# Altium schematic sheet Power.SchDoc
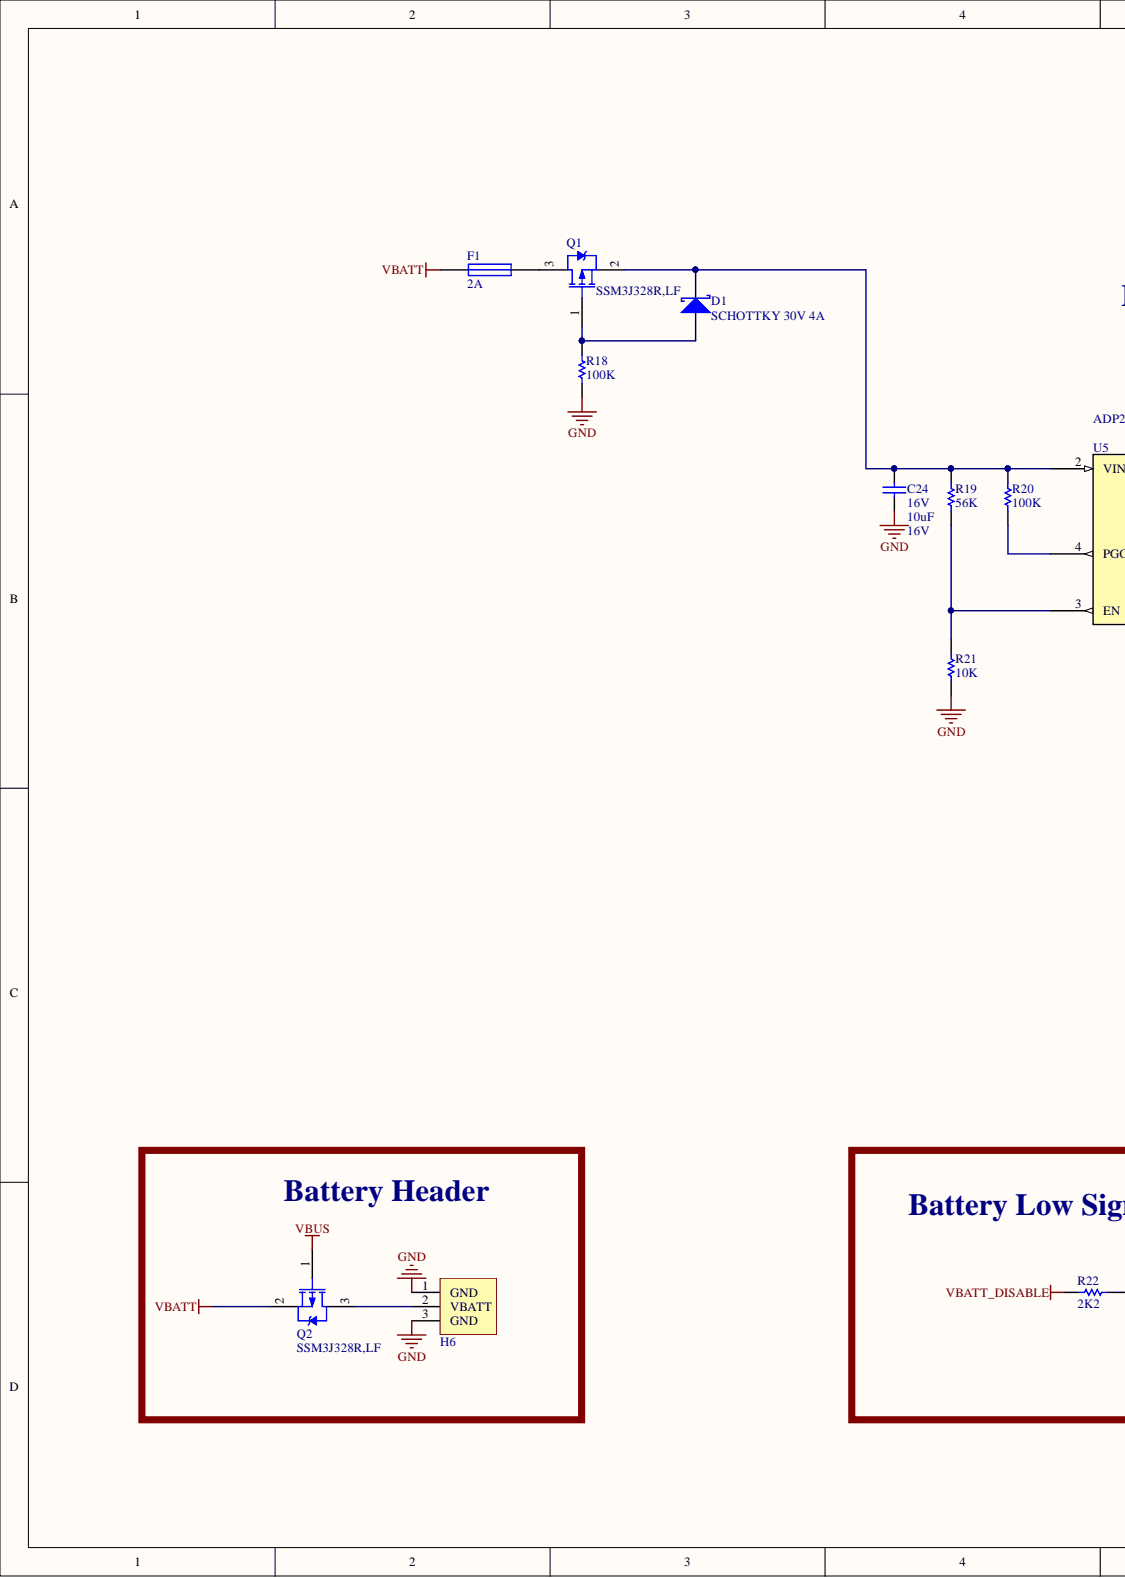
[diagram p0: Power.SchDoc, left]
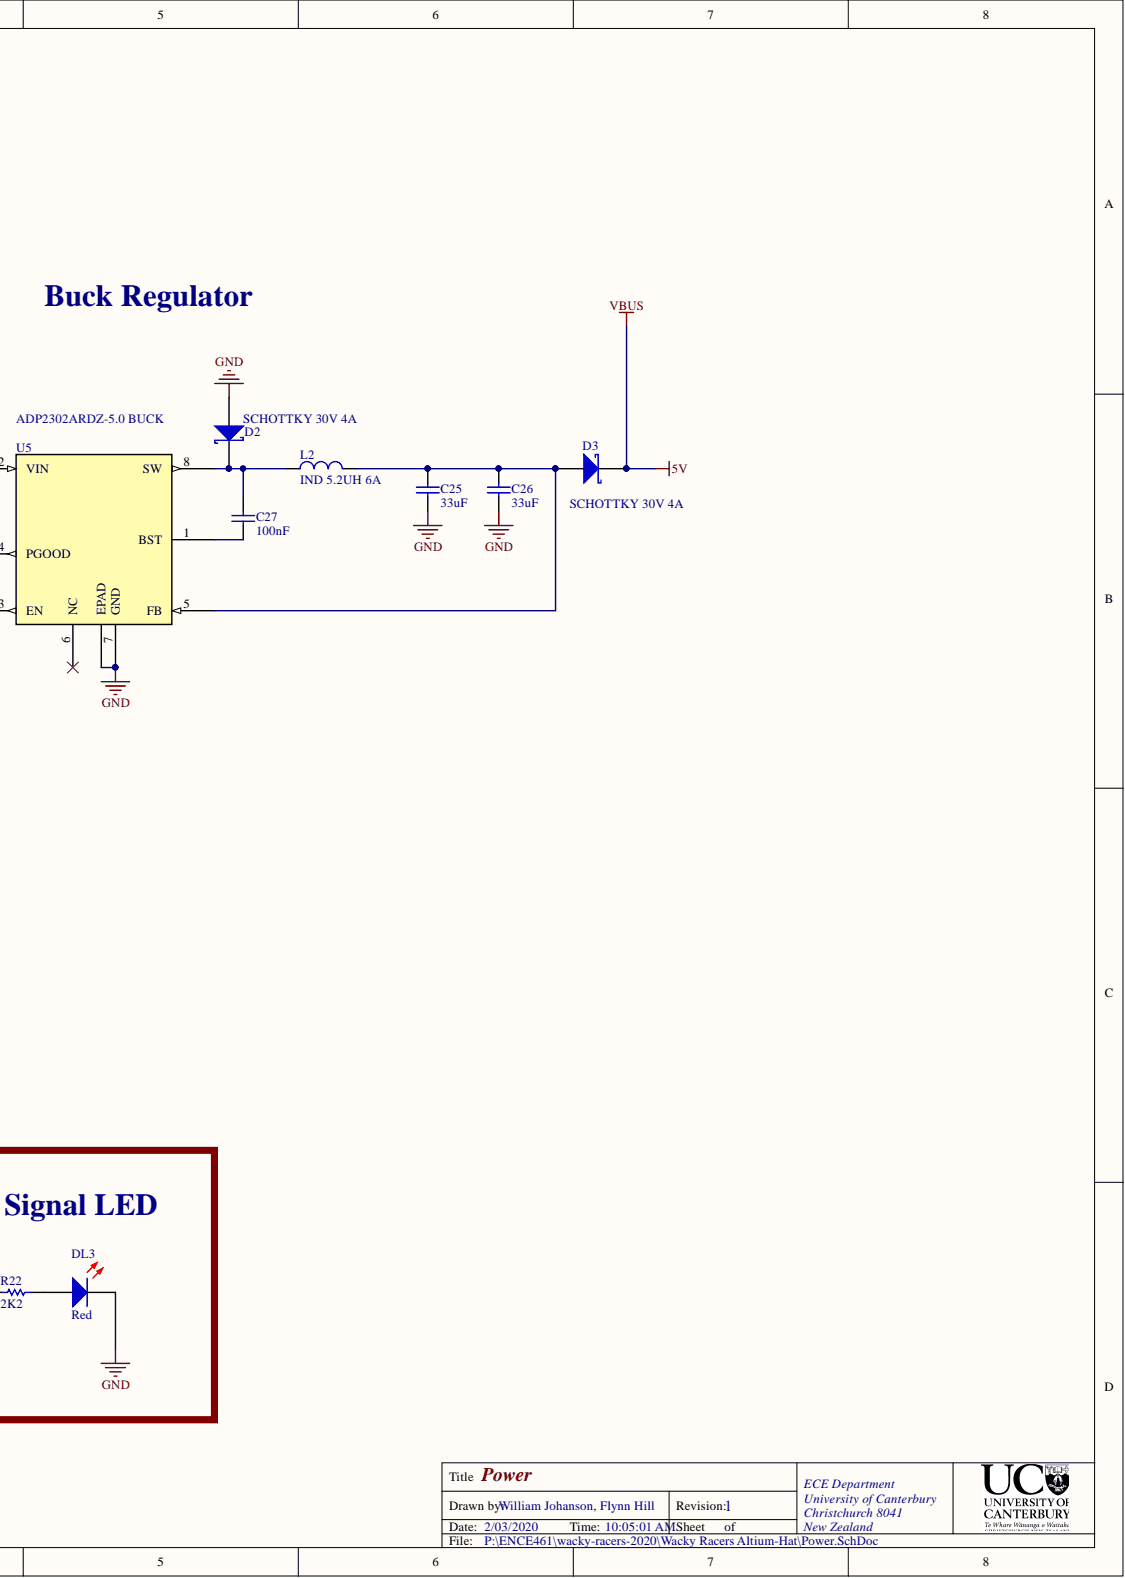
[diagram p1: Power.SchDoc, right]

## Parts
C24  10uF  Max Voltage: 16V  [CAPC2012L]
C25  33uF  [CAPC2012L]
C26  33uF  [CAPC2012L]
C27  100nF  [CAPC1608L]
D1  SCHOTTKY 30V 4A  [SMC]
D2  SCHOTTKY 30V 4A  [SMC]
D3  SCHOTTKY 30V 4A  [SMC]
DL3  Red  [WL-SMCW0603]
F1  2A  [RESC3216N]
H6  Battery_HEADER_3pin  [BATT_HEADER_3POS]  (value not drawn)
L2  IND 5.2UH 6A  [INDUCTOR5u2]
Q1  SSM3J328R,LF  [SOT23_L]
Q2  SSM3J328R,LF  [SOT23_L]
R18  100K  [RESC1608L]
R19  56K  [RESC1608L]
R20  100K  [RESC1608L]
R21  10K  [RESC1608L]
R22  2K2  [RESC1608L]
U5  ADP2302ARDZ-5.0 BUCK  [RD_8_1]

## Nets
5V: D3.2 Q2.1
GND: C24.1 C25.1 C26.1 D2.1 DL3.1 H6.1 H6.3 R18.1 R21.1 U5.7(GND) U5.EPAD
VBATT: F1.2 Q2.2
VBATT_DISABLE: R22.1
NetC24_2: C24.2 D1.2 Q1.2 R19.2 R20.2 U5.2(VIN)
NetC25_2: C25.2 C26.2 D3.1 L2.2 U5.5(FB)
NetC27_1: C27.1 U5.1(BST)
NetC27_2: C27.2 D2.2 L2.1 U5.8(SW)
NetD1_1: D1.1 Q1.1 R18.2
NetDL3_2: DL3.2 R22.2
NetF1_1: F1.1 Q1.3
NetH6_2: H6.2 Q2.3
NetR19_1: R19.1 R21.2 U5.3(EN)
NetR20_1: R20.1 U5.4(PGOOD)

Not connected: U5 6(NC)

## Library data of the parts
C24 = CC_10uF_16V_0805: CAP CER 10uF X5R 16V 0805; Manufacturer: Samsung Electro-Mechanics; Manufacturer Part Number: CL21A106KOQNNWE; Note: Footprint corresponds to dimensions for bulk cassette packaging. This case size has tightened tolerances.; Notification: Current market demand for these product types have resulted in fluctuating and extending lead times. Lead times may differ.; Tolerance: ±10%; Applications: General Purpose; Category: Capacitors; Code_IPC: 2012[0805]; Component Kind: Standard; ComponentLink1Description: http://www.samsungsem.com/kr/support/product-search/mlcc/CL21A106KOQNNWE.jsp; ComponentLink1URL: http://www.samsungsem.com/kr/support/product-search/mlcc/CL21A106KOQNNWE.jsp; Features: -; Height - Seated (Max): -; Lead Spacing: -; Lead Style: -; Library Name: ENCE461AD19_19V2.IntLib; Mounting Type: Surface Mount, MLCC; Operating Temperature: -55°C ~ 85°C; Package / Case: 0805 (2012 Metric); PackageReference: 0805; Packaging: Cut Tape (CT); Part Status: Active; Pricing 1: 1=0.35, 10=0.25, 100=0.1178, 500=0.08254, 1000=0.06831 (NZD); Ratings: -; RoHS: RoHS Compliant; Signal Integrity: C0805; Simulation: CAP; Size / Dimension: 0.079" L x 0.049" W (2.00mm x 1.25mm); Stock 1: 20000; Supplier 1: Digi-Key; Supplier Part Number 1: 1276-2894-1-ND; Temperature Coefficient: X5R; Thickness (Max): 0.055" (1.40mm); Value: 10uF; Voltage - Rated: 16V
C25 C26 = CC_33uF_6V3_0805: CAP CER 33uF X5R 6V3 0805; Manufacturer: TDK Corporation; Manufacturer Part Number: C2012X5R0J336M125AC; Notification: Current market demand for these product types have resulted in fluctuating and extending lead times. Lead times may differ.; Tolerance: ±20%; Voltage - Rated: 6.3V; Applications: General Purpose; Category: Capacitors; Component Kind: Standard; ComponentLink1Description: https://product.tdk.com/info/en/catalog/datasheets/mlcc_commercial_general_en.pdf; ComponentLink1URL: https://product.tdk.com/info/en/catalog/datasheets/mlcc_commercial_general_en.pdf; Failure Rate: -; Features: Low ESL; Height - Seated (Max): -; Lead Spacing: -; Lead Style: -; Library Name: ENCE461AD19_19V2.IntLib; Mounting Type: Surface Mount, MLCC; Operating Temperature: -55°C ~ 85°C; Package / Case: 0805 (2012 Metric); Packaging: Cut Tape (CT); Part Status: Active; Pricing 1: 1=1.65, 10=1.262, 100=0.9023, 500=0.6985, 1000=0.60149 (NZD); Ratings: -; RoHS: RoHS Compliant; Signal Integrity: C0805; Simulation: CAP; Size / Dimension: 0.079" L x 0.049" W (2.00mm x 1.25mm); Stock 1: 20000; Supplier 1: Digi-Key; Supplier Part Number 1: 445-5986-1-ND; Temperature Coefficient: X5R; Thickness (Max): 0.057" (1.45mm); Value: 33uF
C27 = CC_100nF_0603: CAP CER 100nF X7R +-10% 50V 0603; Code_IPC: 1608 [0603]; Component Kind: Standard; Library Name: ECE AD19Components_19V1.IntLib; PackageReference: 0603; Signal Integrity: C0603; Simulation: CAP; Storage Location: PCB Assembly WS; Supplier: ECE Dept; Value: 100nF
D1-D3 = MBRS330T3G: DIODE SCHOTTKY 30V 4A SMC; Manufacturer: ON Semiconductor; Speed: Fast Recovery =< 500ns, > 200mA (Io); Base Part Number: MBRS330; Capacitance @ Vr, F: -; Category: Discrete Semiconductor Products; Component Kind: Standard; ComponentLink1Description: http://www.onsemi.com/pub/Collateral/MBRS340T3-D.PDF; ComponentLink1URL: http://www.onsemi.com/pub/Collateral/MBRS340T3-D.PDF; Current - Average Rectified (Io): 4A; Diode Type: Schottky; Library Name: ENCE461AD19_19V2.IntLib; Manufacturer Part Number: MBRS330T3G; Mounting Type: Surface Mount; Operating Temperature - Junction: -65°C ~ 150°C; Package / Case: DO-214AB, SMC; Packaging: Cut Tape (CT); Part Status: Active; Pricing 1: 1=0.93, 10=0.79, 100=0.5902, 500=0.46368, 1000=0.35829 (NZD); RoHS: RoHS Compliant; Stock 1: 8919; Supplier 1: Digi-Key; Supplier Device Package: SMC; Supplier Part Number 1: MBRS330T3GOSCT-ND
DL3 = DL_150060RS75000: LED Red 250mcd 0603 SMD; Manufacturer: WURTH ELEKTRONIK; Forward Current If: 30; Forward Voltage: 2; Lens Shape: Rectangular; Luminous Intensity: 250; Viewing Angle: 140°; Wavelength Typ: 625; Component Kind: Standard; LED Colour: Red; LED Mounting: SMD; Library Name: ECE AD19Components_19V1.IntLib; Manufacturer Part Number: 150060RS75000; Storage Location: PCB Assembly WS; Supplier: ECE Dept; Supplier 1: Farnell; Supplier Part Number 1: 2322071
F1 = FS_Fuse_2.0A: Fuse 2.0A Very Fast Acting 1206 SMD; Manufacturer: MULTICOMP; Manufacturer Part Number: MC12CF 002; Component Kind: Standard; Library Name: ECE AD19Components_19V1.IntLib; Manufacturer PN: MC12CF 002; Storage Location: PCB Assembly WS; Supplier: ECE Dept; Supplier 1: Farnell; Supplier Part Number 1: 1841029; Value: 2A
H6 = Battery_HEADER_3pin: 3Pin Header 2.54mm spacing; Component Kind: Standard; Library Name: ENCE461AD19_19V2.IntLib
L2 = CDRH104RNP-5R2NC: FIXED IND 5.2UH 6A 22 MOHM SMD; Manufacturer: Sumida America Components Inc.; Current - Saturation: 5.6A; DC Resistance (DCR): 22 mOhm Max; Material - Core: Ferrite; Shielding: Shielded; Tolerance: ±30%; Category: Inductors, Coils, Chokes; Component Kind: Standard; ComponentLink1Description: http://products.sumida.com/products/pdf/CDRH104R.pdf; ComponentLink1URL: http://products.sumida.com/products/pdf/CDRH104R.pdf; Frequency - Self Resonant: -; Height - Seated (Max): 0.157" (4.00mm); Library Name: ENCE461AD19_19V2.IntLib; Manufacturer Part Number: CDRH104RNP-5R2NC; Mounting Type: Surface Mount; Operating Temperature: -40°C ~ 100°C; Package / Case: Nonstandard; Packaging: Cut Tape (CT); Part Status: Active; Pricing 1: 1=2.9, 10=2.678, 100=1.8743, 500=1.5173 (NZD); Q @ Freq: -; Ratings: -; RoHS: RoHS Compliant; Size / Dimension: 0.402" L x 0.394" W (10.20mm x 10.00mm); Stock 1: 3849; Supplier 1: Digi-Key; Supplier Device Package: -; Supplier Part Number 1: 308-2280-1-ND; Type: -
Q1 Q2 = TM_SSM3J328R: MOSFET P-CH 20V 6A SOT23-3; Manufacturer: Toshiba; Drain to Source Breakdown Voltage: -20V; Drain to Source Resistance: 47.4mR; Element Configuration: Single; FET Feature: Logic Level Gate, 1.5V Drive; FET Type: MOSFET P-Channel, Metal Oxide; Gate Charge (Qg) @ Vgs: 12.8nC @ 4.5V; Gate to Source Voltage (Vgs): 8V; Input Capacitance: 840pF; Input Capacitance (Ciss) @ Vds: 840pF @ 10V; Max Power Dissipation: 1W; Number of Channels: 1; Power Dissipation: 2W; Rds On Max: 29.8mR; Turn-Off Delay Time: 107ns; Turn-On Delay Time: 32ns; Vgs(th) (Max) @ Id: 1V @ 1mA; Case/Package: SOT-23-3; Component Kind: Standard; ComponentLink1Description: https://datasheet.ciiva.com/11158/ssm3j328r-datasheet-en-20140301-11158652.pdf; ComponentLink1URL: https://datasheet.ciiva.com/11158/ssm3j328r-datasheet-en-20140301-11158652.pdf; Continuous Drain Current (ID): 6A; Current - Continuous Drain (Id) @ 25° C: 6A; Drain to Source Voltage (Vdss): 20V; Library Name: ECE AD19Components_19V1.IntLib; Manufacturer Part Number: SSM3J328R,LF; Max Operating Temperature: 150°C; Min Operating Temperature: -55°C; Mount: Surface Mount; Number of Elements: 1; Number of Pins: 3; Package / Case: SOT-23-3 Flat Leads; Package Quantity: 3000; Packaging: Tape and Reel; Power - Max: 1W; Pricing: 1=0.79, 10=0.658, 25=0.5772, 100=0.4939, 250=0.42836, 500=0.36284, 1000=0.27972 (NZD); Radiation Hardening: No; Storage Location: PCB Assembly WS; Supplier: Digi-Key; Supplier 1: Digi-Key; Supplier Device Package: SOT-23F; Supplier Part Number: SSM3J328RLFDKR-ND; Supplier Part Number 1: SSM3J328RLFDKR-ND
R18 R20 = RS_100K: RES 100K OHM 1/10W 5% 0603 SMD; Component Kind: Standard; Library Name: ECE AD19Components_19V1.IntLib; Signal Integrity: Res; Simulation: RESISTOR; Storage Location: PCB Assembly WS; Supplier: ECE Dept; Value: 100K
R19 = RS_56K: RES 56.0K OHM 1/10W 5% 0603 SMD; Component Kind: Standard; Library Name: ECE AD19Components_19V1.IntLib; Signal Integrity: Res; Simulation: RESISTOR; Storage Location: PCB Assembly WS; Supplier: ECE Dept; Value: 56K
R21 = RS_10K: RES 10.0K OHM 1/10W 5% 0603 SMD; Component Kind: Standard; Library Name: ECE AD19Components_19V1.IntLib; Signal Integrity: Res; Simulation: RESISTOR; Storage Location: PCB Assembly WS; Supplier: ECE Dept; Value: 10K
R22 = RS_2K2: RES 2.2K OHM 1/10W 5% 0603 SMD; Component Kind: Standard; Library Name: ECE AD19Components_19V1.IntLib; Signal Integrity: Res; Simulation: RESISTOR; Storage Location: PCB Assembly WS; Supplier: ECE Dept; Value: 2K2
U5 = ADP2302ARDZ-5.0-R7: IC REG BUCK 5V 2A 8SOIC; Frequency - Switching: 700kHz; Output Type: Fixed; Vendor: Analog Devices Inc; Voltage - Input (Max): 20V; Component Kind: Standard; Current - Output: 2A; Library Name: ENCE461AD19_19V2.IntLib; Manufacturer_Part_Number: ADP2302ARDZ-5.0-R7; Supplier 1: Digi-Key; Supplier Part Number 1: ADP2302ARDZ-5.0-R7CT-ND; Type: DEV; Value: Value; Voltage - Output (Min/Fixed): 5V
